# Altium schematic sheet lv_signal_air.SchDoc
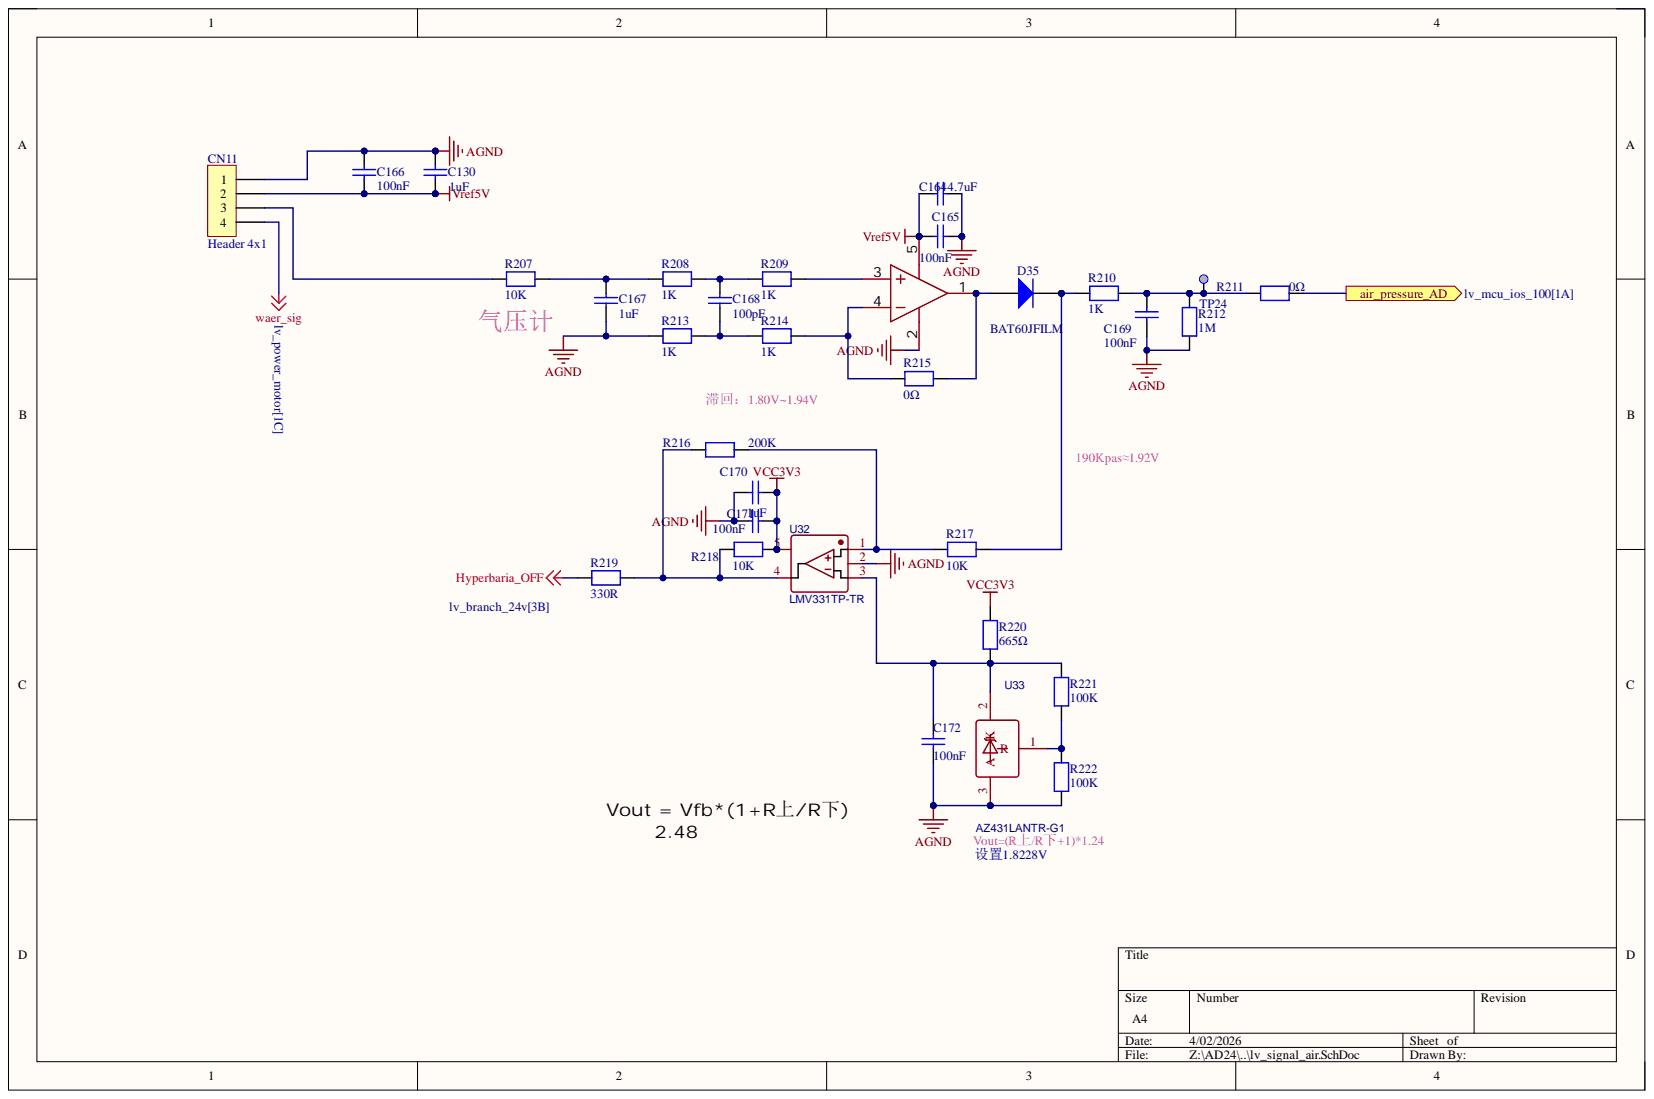
[diagram p0: lv_signal_air.SchDoc]

## Parts
C130  1uF  [C0603]
C164  4.7uF  [C0603]
C165  100nF  [C0402]
C166  100nF  [C0402]
C167  1uF  [C0603]
C168  100pF  [C0402]
C169  100nF  [C0402]
C170  1uF  [C0603]
C171  100nF  [C0402]
C172  100nF  [C0402]
CN11  Header 4x1  [CON_SAMTEC_TSW-104-07-G-S]
D35  BAT60JFILM  [DIO_SOD323]
R207  10K  [R0402]
R208  1K  [R0402]
R209  1K  [R0402]
R210  1K  [R0402]
R211  0Ω  [R0402]
R212  1M  [R0402]
R213  1K  [R0402]
R214  1K  [R0402]
R215  0Ω  [R0402]
R216  200K  [R0402]
R217  10K  [R0402]
R218  10K  [R0402]
R219  330R  [R0402]
R220  665Ω  [R0402]
R221  100K  [R0402]
R222  100K  [R0402]
TP24  AIRADC  [TP_SMD_1MM]  (value not drawn)  (no BOM)
U30  TLV271CDBVR  [SOT-23-5_L3.0-W1.7-P0.95-LS2.8-BR]  (value not drawn)
U32  LMV331TP-TR  [SOT-23-5_L3.0-W1.7-P0.95-LS2.8-BR]
U33  AZ431LANTR-G1  [SOT-23-3_L2.9-W1.6-P1.90-LS2.8-BR]

## Ports
air_pressure_AD

## Nets
AGND: C130.2 C164.1 C165.2 C166.1 C167.2 C169.1 C170.1 C171.2 C172.2 CN11.1 R212.1 R213.1 R222.1 U30.2 U32.2 U33.3
Hyperbaria_OFF: R219.1
VCC3V3: C170.2 C171.1 R218.2 R220.2 U32.5
Vref5V: C130.1 C164.2 C165.1 C166.2 CN11.2 U30.5
waer_sig: CN11.4
air_pressure_AD: R211.1 ; port air_pressure_AD
NetC167_1: C167.1 R207.2 R208.1
NetC168_1: C168.1 R208.2 R209.1
NetC168_2: C168.2 R213.2 R214.1
NetC169_2: C169.2 R210.2 R211.2 R212.2 TP24.1
NetC172_1: C172.1 R220.1 R221.2 U32.3 U33.2
NetCN11_3: CN11.3 R207.1
NetD35_1: D35.1 R215.1 U30.1
NetD35_2: D35.2 R210.1 R217.1
NetR209_2: R209.2 U30.3
NetR214_2: R214.2 R215.2 U30.4
NetR216_1: R216.1 R217.2 U32.1
NetR216_2: R216.2 R218.1 R219.2 U32.4
NetR221_1: R221.1 R222.2 U33.1

## Library data of the parts
C130 C167 = Capacitor_1: 50V, X5R, 10%; Manufacturer: muRata; Manufacturer_Part_Number: GRM188R61H105KAALD; Component Kind: Standard; ComponentLink1Description: Yageo_Capacitor_X5R-series.pdf; ComponentLink1URL: http://tunmcdsvn.tun.st.com/Component_Datasheet_Library/Trunk/Capacitors/Yageo_Multilayer_capacitor_X5R_series_100pF_to_100uF; Library Name: HiPin_Library_AD.IntLib
C164 = Capacitor_1: 16V, X7S, 10%; Internal_Part_Number: MCD-00009; Manufacturer: muRata; Manufacturer_Part_Number: GRM188C71C475KE21D; Component Kind: Standard; ComponentLink1Description: Yageo_Capacitor_X5R-series.pdf; ComponentLink1URL: http://tunmcdsvn.tun.st.com/Component_Datasheet_Library/Trunk/Capacitors/Yageo_Multilayer_capacitor_X5R_series_100pF_to_100uF; Library Name: HiPin_Library_AD.IntLib; Package: C0603; Value: 4.7uF
C165 C166 C169 = Capacitor: 50V, 5%, X7R; Manufacturer: muRata; Manufacturer_Part_Number: GRM155R71H104KE14D; Component Kind: Standard; ComponentLink1Description: Datasheet; ComponentLink1URL: http://tunmcdsvn.tun.st.com/Component_Datasheet_Library/Trunk/Capacitors/Yageo_Capacitor_NP0_16V-to-50V; Library Name: HiPin_Library_AD.IntLib
C168 = Capacitor: 50V, 5%, C0G; Manufacturer: muRata; Manufacturer_Part_Number: GRM1555C1H101JA01D; Component Kind: Standard; ComponentLink1Description: Datasheet; ComponentLink1URL: http://tunmcdsvn.tun.st.com/Component_Datasheet_Library/Trunk/Capacitors/Yageo_Capacitor_NP0_16V-to-50V; Library Name: HiPin_Library_AD.IntLib
C170 = MCD-00010: Capacitor, MLCC, 1uF, 16V, X5R, 10%, 0603, T=0,8mm; Manufacturer: YAGEO; Manufacturer_Part_Number: CC0603KRX5R7BB105; Database Table Name: MCD_PARTS$; Initial_Board_Reference: MB1274; Provided_By: Subcon; Component Kind: Standard; ComponentLink1Description: Yageo_Capacitor_X5R-series.pdf; ComponentLink1URL: http://tunmcdsvn.tun.st.com/Component_Datasheet_Library/Trunk/Capacitors/Yageo_Multilayer_capacitor_X5R_series_100pF_to_100uF; ECCN_EU: n/a; ECCN_US: n/a; Internal_Part_Number: MCD-00010; Library Name: MCD_PARTS_database.DbLib; Package: C0603; Part_Type: Capacitor; Status: Released; Value: 1uF
C171 C172 = Capacitor: 50V, 10%, X7R; Internal_Part_Number: MCD-00003; Manufacturer: muRata; Manufacturer_Part_Number: GRM155R71H104KE14D; Component Kind: Standard; ComponentLink1Description: Datasheet; ComponentLink1URL: http://tunmcdsvn.tun.st.com/Component_Datasheet_Library/Trunk/Capacitors/Yageo_Capacitor_NP0_16V-to-50V; Library Name: HiPin_Library_AD.IntLib; Package: C0402; Value: 100nF
CN11 = Header 4x1: Header, 4x1, 2,54mm, VR, TH, Height 5.84mm/2.54mm; Internal_Part_Number: MCD-00029; Manufacturer: SAMTEC; Manufacturer_Part_Number: TSW-104-07-x-S; Part_Type: Connector; Initial_Board_Reference: MB1274; Provided_By: Subcon; Component Kind: Standard; ComponentLink1Description: Samtec_connector_TSW-HTSW-series.pdf; ComponentLink1URL: http://tunmcdsvn.tun.st.com/Component_Datasheet_Library/Trunk/Connectors/Samtec_TSW-HTSW-series; ECCN_EU: n/a; ECCN_US: n/a; Library Name: HiPin_Library_AD.IntLib; Status: Released; Value: Header 4x1
D35 = BAT60JFILM: Internal_Part_Number: MCD-00034; Manufacturer: STMICROELECTRONICS; Part_Type: Diode; ECCN_EU: NEC; ECCN_US: EAR99; Initial_Board_Reference: MB1274; Provided_By: Subcon; Component Kind: Standard; ComponentLink1Description: STM_Diode_BAT60JFILM-SOD323.pdf; ComponentLink1URL: http://tunmcdsvn.tun.st.com/Component_Datasheet_Library/Trunk/Diodes/STM_Diode_BAT60JFILM-SOD323; Description: BAT60JFILM; Library Name: HiPin_Library_AD.IntLib; Manufacturer_Part_Number: BAT60JFILM; Status: Released; Value: BAT60JFILM
R207 R217 R218 = RES: 1%; Component Kind: Standard; Library Name: HiPin_Library_AD.IntLib; Value: 10K
R208-R216 R219 = RES: 1%; Value: 10K; Component Kind: Standard; Library Name: HiPin_Library_AD.IntLib
R220-R222 = RES: Resistor; Component Kind: Standard; Library Name: HiPin_Library_AD.IntLib
TP24 = MCD-00074: Test Point 1mm; Part_Type: Mechanical; Database Table Name: MCD_PARTS$; ComponentLink1Description: Datasheet; ComponentLink1URL: http://na; ECCN_EU: n/a; ECCN_US: n/a; Internal_Part_Number: MCD-00074; Library Name: MCD_PARTS_database.DbLib; Manufacturer: n/a; Manufacturer_Part_Number: n/a; Provided_By: n/a; Status: Released
U30 = TLV271CDBVR: Manufacturer: TI(德州仪器); Add into BOM: yes; Current - Input Bias(Ib): 1pA; Gain Bandwidth Product(GBP): 3MHz; Number of Circuits: 单路; Output Current: 8mA; Slew Rate(SR): 2.6V/us; Supply Current: 625uA; Component Kind: Standard; Convert to PCB: yes; Datasheet: https://www.ti.com/cn/lit/gpn/tlv271; Device: TLV271CDBVR; JLCPCB Part Class: Extended Part; LCSC Part Name: TLV271CDBVR; Library Name: HiPin_Library_AD.IntLib; Manufacturer Part: TLV271CDBVR; Operating Temperature: 0℃~+70℃; Output Type: -; Rail to Rail: -; Supplier: LCSC; Supplier Footprint: SOT-23-5; Supplier Part: C131948; Symbol: TLV271CDBVR
U32 = LMV331TP-TR: 比较器; BOM_Manufacturer: 3PEAK; Contributor: LCSC; BOM_JLCPCB Part Class: Extended Part; BOM_Manufacturer Part: LMV331TP-TR; BOM_Supplier: LCSC; BOM_Supplier Part: C108095; Component Kind: Standard; Library Name: HiPin_Library_AD.IntLib; spicePre: U; spiceSymbolName: LMV331TP-TR
U33 = TL431: BOM_Manufacturer: JSMSEMI; Contributor: LCSC; BOM_JLCPCB Part Class: Extended Part; BOM_Manufacturer Part: TL431; BOM_Supplier: LCSC; BOM_Supplier Part: C963380; Component Kind: Standard; Library Name: HiPin_Library_AD.IntLib; spicePre: U; spiceSymbolName: TL431_C963380
